# Altium schematic sheet SDRAM.SchDoc
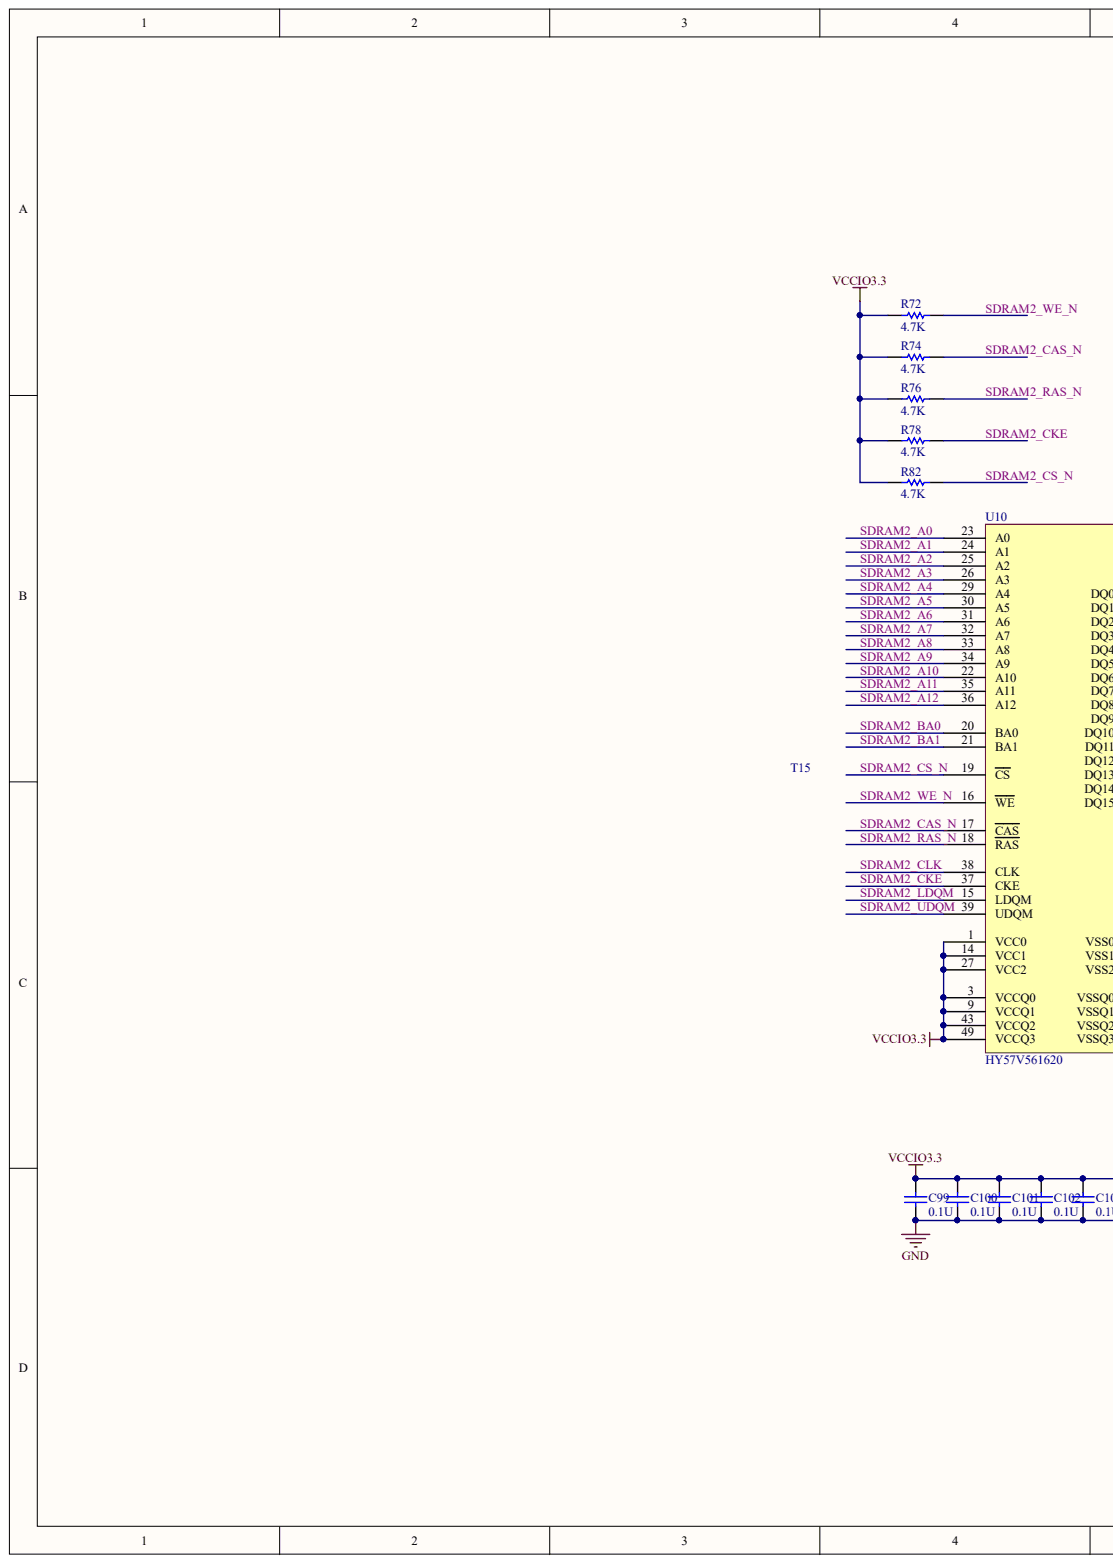
[diagram p0: SDRAM.SchDoc, left]
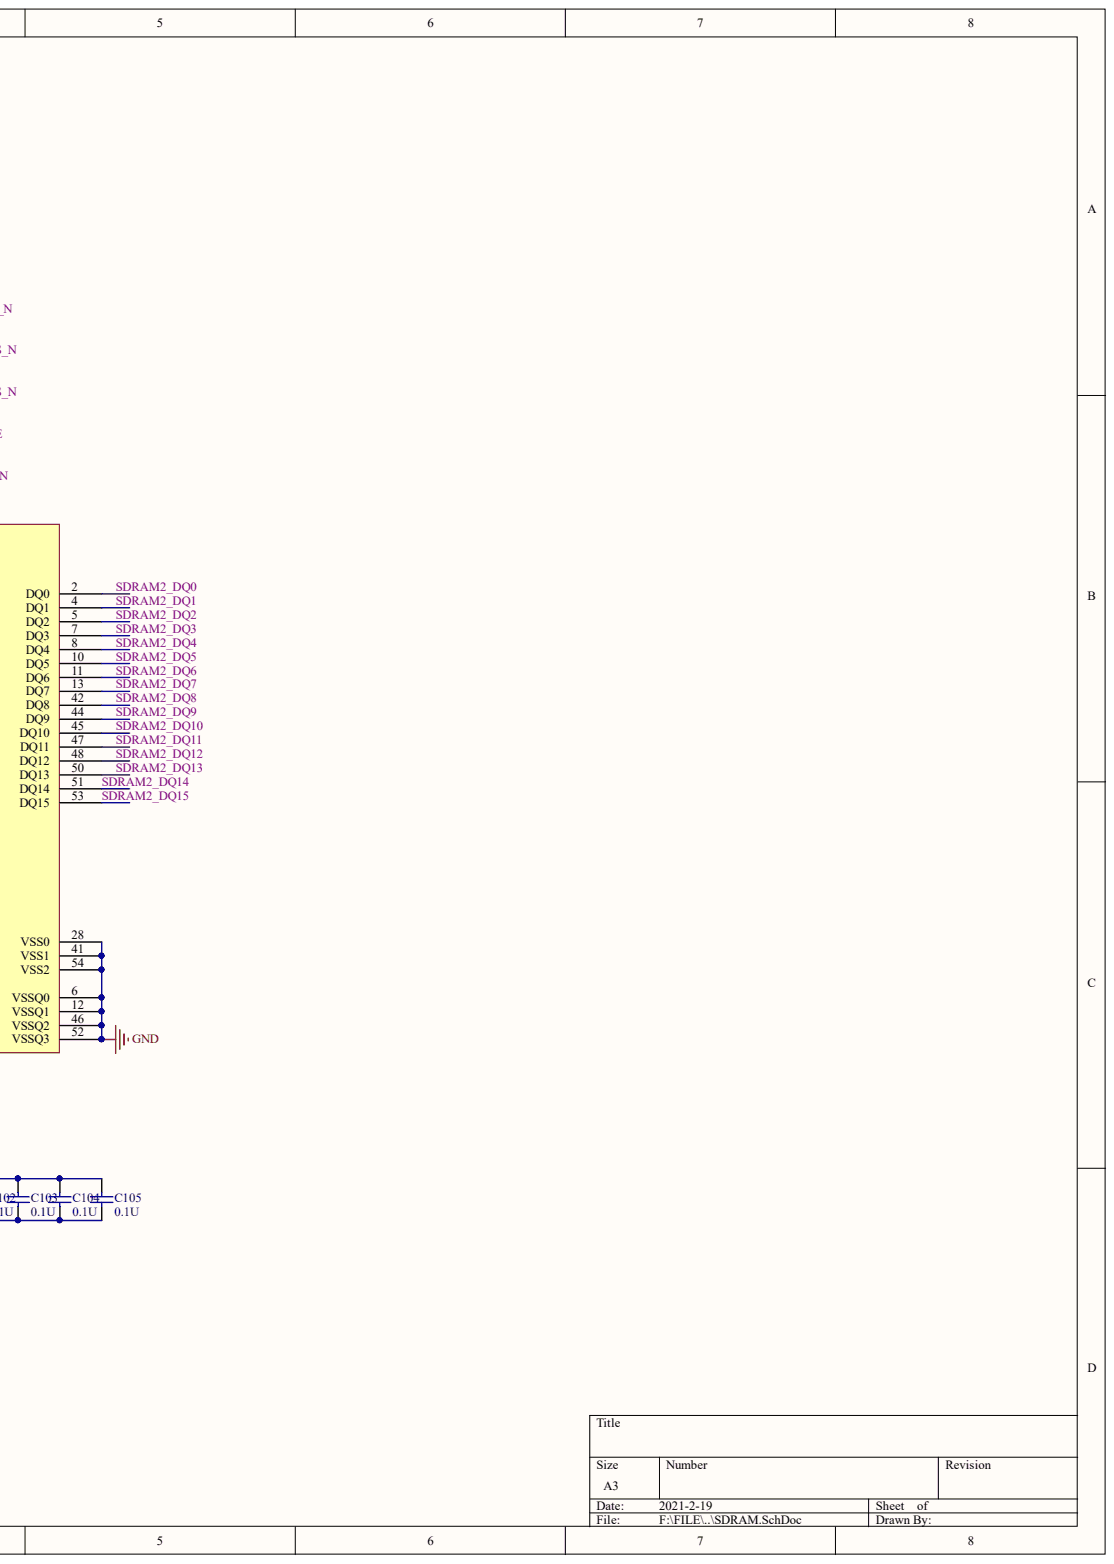
[diagram p1: SDRAM.SchDoc, right]

## Parts
C99  0.1U  [0603C]
C100  0.1U  [0603C]
C101  0.1U  [0603C]
C102  0.1U  [0603C]
C103  0.1U  [0603C]
C104  0.1U  [0603C]
C105  0.1U  [0603C]
R72  4.7K  [0603_Q0603A]
R74  4.7K  [0603_Q0603A]
R76  4.7K  [0603_Q0603A]
R78  4.7K  [0603_Q0603A]
R82  4.7K  [0603_Q0603A]
U10  HY57V561620  [TSOP54]

## Nets
GND: C99.1 C100.1 C101.1 C102.1 C103.1 C104.1 C105.1 U10.6(VSSQ0) U10.12(VSSQ1) U10.28(VSS0) U10.41(VSS1) U10.46(VSSQ2) U10.52(VSSQ3) U10.54(VSS2)
VCCIO3.3: C99.2 C100.2 C101.2 C102.2 C103.2 C104.2 C105.2 R72.1 R74.1 R76.1 R78.1 R82.1 U10.1(VCC0) U10.3(VCCQ0) U10.9(VCCQ1) U10.14(VCC1) U10.27(VCC2) U10.43(VCCQ2) U10.49(VCCQ3)
SDRAM2_A0: U10.23(A0)
SDRAM2_A1: U10.24(A1)
SDRAM2_A2: U10.25(A2)
SDRAM2_A3: U10.26(A3)
SDRAM2_A4: U10.29(A4)
SDRAM2_A5: U10.30(A5)
SDRAM2_A6: U10.31(A6)
SDRAM2_A7: U10.32(A7)
SDRAM2_A8: U10.33(A8)
SDRAM2_A9: U10.34(A9)
SDRAM2_A10: U10.22(A10)
SDRAM2_A11: U10.35(A11)
SDRAM2_A12: U10.36(A12)
SDRAM2_BA0: U10.20(BA0)
SDRAM2_BA1: U10.21(BA1)
SDRAM2_CAS_N: R74.2 U10.17(CAS)
SDRAM2_CKE: R78.2 U10.37(CKE)
SDRAM2_CLK: U10.38(CLK)
SDRAM2_CS_N: R82.2 U10.19(CS)
SDRAM2_DQ0: U10.2(DQ0)
SDRAM2_DQ1: U10.4(DQ1)
SDRAM2_DQ2: U10.5(DQ2)
SDRAM2_DQ3: U10.7(DQ3)
SDRAM2_DQ4: U10.8(DQ4)
SDRAM2_DQ5: U10.10(DQ5)
SDRAM2_DQ6: U10.11(DQ6)
SDRAM2_DQ7: U10.13(DQ7)
SDRAM2_DQ8: U10.42(DQ8)
SDRAM2_DQ9: U10.44(DQ9)
SDRAM2_DQ10: U10.45(DQ10)
SDRAM2_DQ11: U10.47(DQ11)
SDRAM2_DQ12: U10.48(DQ12)
SDRAM2_DQ13: U10.50(DQ13)
SDRAM2_DQ14: U10.51(DQ14)
SDRAM2_DQ15: U10.53(DQ15)
SDRAM2_LDQM: U10.15(LDQM)
SDRAM2_RAS_N: R76.2 U10.18(RAS)
SDRAM2_UDQM: U10.39(UDQM)
SDRAM2_WE_N: R72.2 U10.16(WE)

## Library data of the parts
C99-C105 = CAP1: Component Kind: Standard; Library Name: the_god_of_death.IntLib
R72 R74 R76 R78 R82 = RES: Component Kind: Standard; Library Name: the_god_of_death.IntLib
U10 = HY57V561620: Component Kind: Standard; Library Name: the_god_of_death.IntLib
